# Altium schematic sheet Comperator.SchDoc
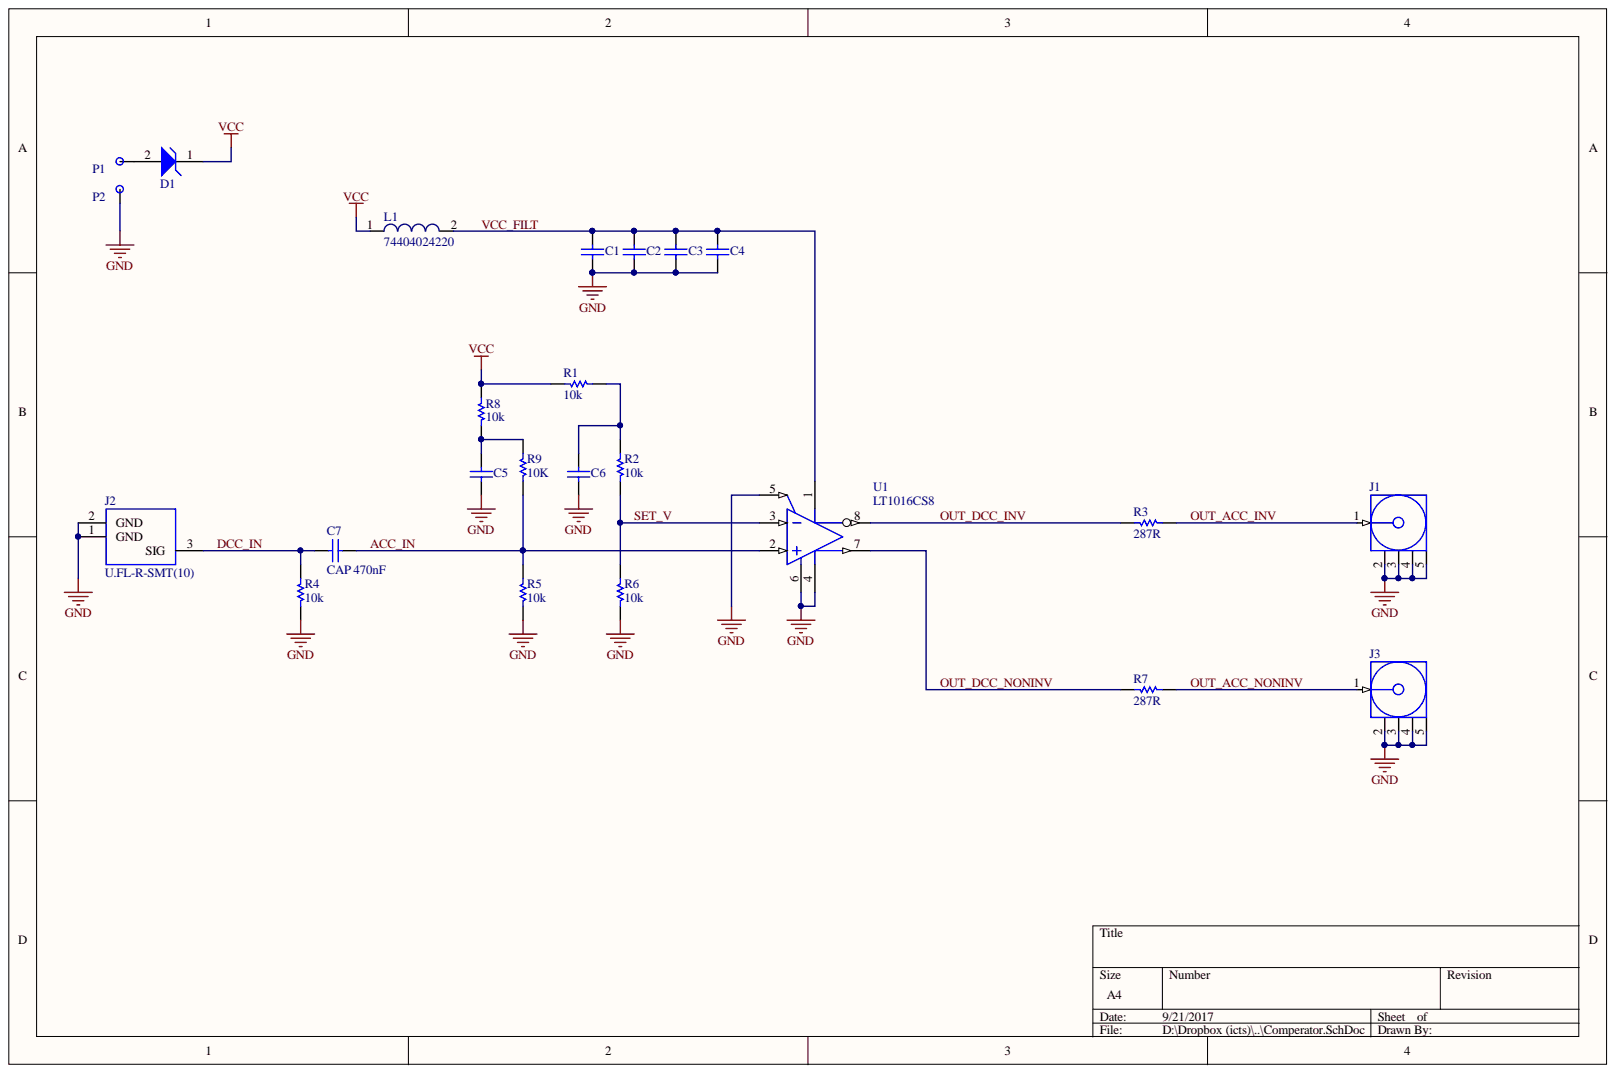
[diagram p0: Comperator.SchDoc]

## Parts
C1  CAP 10uF 10V 1206(3216)  [CAPC1206(3216)130_L]  (value not drawn)
C2  CAP 1uF 6.3V 0805(2012)  [CAPC0805(2012)100_L]  (value not drawn)
C3  CAP 1uF 6.3V 0805(2012)  [CAPC0805(2012)100_L]  (value not drawn)
C4  CAP 1uF 6.3V 0805(2012)  [CAPC0805(2012)100_L]  (value not drawn)
C5  CAP 1uF 6.3V 0805(2012)  [CAPC0805(2012)100_L]  (value not drawn)
C6  CAP 1uF 6.3V 0805(2012)  [CAPC0805(2012)100_L]  (value not drawn)
C7  CAP 470nF  value 470nF  [CAPC1210(3225)130_M]
D1  1SMF5920BT1G  [ONSC-SOD123-2-498_A_V]  (value not drawn)
J1  CONREVSMA003.062  [CON-SMA-EDGE062]  (value not drawn)
J2  U.FL-R-SMT(10)  [HRS-U.FL-R-SMT_V]
J3  CONREVSMA003.062  [CON-SMA-EDGE062]  (value not drawn)
L1  74404024220  [WE-LQS 252012]
P1  PinHeader 2.54mm 1x1  [PinHeader]  (value not drawn)
P2  PinHeader 2.54mm 1x1  [PinHeader]  (value not drawn)
R1  10k  [RESC0805(2012)_L]
R2  10k  [RESC0805(2012)_L]
R3  287R  [RESC0805(2012)_L]
R4  10k  [RESC0805(2012)_L]
R5  10k  [RESC0805(2012)_L]
R6  10k  [RESC0805(2012)_L]
R7  287R  [RESC0805(2012)_L]
R8  10k  [RESC0805(2012)_L]
R9  10K  [RESC0805(2012)_L]
U1  LT1016CS8  [LT-S8_N]

## Nets
GND: C1.1 C2.1 C3.1 C4.1 C5.1 C6.1 J1.2 J1.3 J1.4 J1.5 J2.1 J2.2 J3.2 J3.3 J3.4 J3.5 P2.1 R4.2 R5.2 R6.2 U1.4 U1.5 U1.6
VCC: D1.1 L1.1 R1.1 R8.1
ACC_IN: C7.1 R5.1 R9.2 U1.2
DCC_IN: C7.2 J2.3 R4.1
OUT_ACC_INV: J1.1 R3.1
OUT_ACC_NONINV: J3.1 R7.1
OUT_DCC_INV: R3.2 U1.8
OUT_DCC_NONINV: R7.2 U1.7
SET_V: R2.2 R6.1 U1.3
VCC_FILT: C1.2 C2.2 C3.2 C4.2 L1.2 U1.1
NetC5_2: C5.2 R8.2 R9.1
NetC6_2: C6.2 R1.2 R2.1
NetD1_2: D1.2 P1.1

## Library data of the parts
C1 = CMP-1037-04970-1: CAP 10uF 10V -20% to +80% 1206 (3216 Metric) Thickness 1.3mm SMD; Case-EIA: 1206; Case-Metric: 3216; Component Kind: Standard; Library Name: Altium Content Vault; Max Thickness: 1.3 mm; Rated Voltage: 10 V; Technology: SMT; Tolerance: -20% to +80%; Value: 10uF
C2-C6 = CMP-1036-04734-1: CAP 1uF 6.3V ±20% 0805 (2012 Metric) Thickness 1mm SMD; Case-EIA: 0805; Case-Metric: 2012; Tolerance: Â±20%; Component Kind: Standard; Library Name: Altium Content Vault; Max Thickness: 1 mm; Rated Voltage: 6.3 V; Technology: SMT; Value: 1uF
C7 = CMP-1038-01934-1: CAP 470nF 50V ±10% 1210 (3225 Metric) Thickness 1.3mm SMD; Case-EIA: 1210; Case-Metric: 3225; Tolerance: Â±10%; Component Kind: Standard; Library Name: Altium Content Vault; Max Thickness: 1.3 mm; Rated Voltage: 50 V; Technology: SMT
D1 = CMP-1060-00636-1: 2.5 W Zener Diode, 2-Pin SOD123, Pb-Free, Tape and Reel; Manufacturer: On Semiconductor; VZ Typ (V): 6.2; Component Kind: Standard; ComponentLink1Description: Manufacturer URL; ComponentLink1URL: http://www.onsemi.com/; ComponentLink2Description: Datasheet; ComponentLink2URL: http://www.onsemi.cn/pub_link/Collateral/1SMF5920B-D.PDF; ComponentLink3Description: Package Specification; ComponentLink3URL: http://www.onsemi.cn/pub_link/Collateral/1SMF5920B-D.PDF; DatasheetVersion: Rev. P0; Library Name: Altium Content Vault; Mounting Technology: Surface Mount; PackageDescription: 2-Pin SOD, Body 1.65 x 2.7 mm; PackageReference: SOD-123-2-498_A; PackageVersion: Rev. A; Packing: Tape and Reel; PartNumber: 1SMF5920BT1G; RoHS: Pb-Free
J1 J3 = CMP-0168-00046-1: PCB_Layout: Pads complies with manufacturer's recommendation.; Component Kind: Standard; DatasheetVersion: rev1.0, Jun-2006; Library Name: Altium Content Vault; PackageReference: CON-SMA-EDGE062; PackageVersion: rev1.0, Jun-2006
J2 = CMP-0029-00003-1: Ultra Small Surface Mount Coaxial Connector, 50 Ohm, -40 to 90 degC, 3-Pin SMD, RoHS, Tape and Reel; Current Rating: 3 A; Colour: Black; Component Kind: Standard; ComponentLink1Description: Manufacturer URL; ComponentLink1URL: https://www.hirose.com; ComponentLink2Description: Datasheet; ComponentLink2URL: https://www.hirose.com/product/en/download_file/key_name/U.FL/category/Catalog/doc_file_id/31697/?file_category_id=4&item_id=15&is_series=1; Contact Finish: Lead; Contact Material: Bronze; Contact Plating: Tin; DatasheetVersion: Aug-16; Height: 8.13 mm; Lead Pitch: 2.54 mm; Length: 101.19 mm; Library Name: Altium Content Vault; Max Operating Temperature: 105degC; Min Operating Temperature: -65degC; Mount: Through Hole; PackageDescription: 3-Pin Surface Mount Device, Body 2.6 x 2.6 mm; Package Quantity: 50; PackageReference: U.FL-R-SMT; Packaging: Bulk; Pins: 80; Radiation Hardening: No; RoHSCompliant: True; Width: 4.88 mm
L1 = CMP-1354-00001-1: WE-LQS SMD Power Inductor, L=22.0 µH; Manufacturer: Wuerth Elektronik; Inductance: 22.0 ÂµH; Component Kind: Standard; ComponentLink1Description: Manufacturer URL; ComponentLink1URL: http://www.we-online.com; ComponentLink2Description: Datasheet; ComponentLink2URL: http://katalog.we-online.de/pbs/datasheet/74404024220.pdf; Library Name: Altium Content Vault; PackageReference: WE-LQS 252012; PartNumber: 74404024220
R1-R9 = CMP-1013-00180-1: 1R21 0.125W 1% 0805 (2012 Metric)  SMD; Case-EIA: 0805; Case-Metric: 2012; Value: 1.21 Ohm; Component Kind: Standard; Library Name: Altium Content Vault; Power: 0.125 W; Technology: SMT; Tolerance: 1 %
U1 = CMP-0360-00596-2: UltraFast Precision 10 ns Comparator, 1 Channel, 4.5 to + / - 5 V, 0 to +70 degC, 8-pin SOP (S8); Generic Part Number: LT1016; Manufacturer: Linear Technology; Channels (#): 1; Prop Delay (us): 0.009; Supply Current (uA) Typical: 35000; Vos (mV) Guaranteed: 3; Vs Max (V): 10; Vs Min (V): 4.5; Component Kind: Standard; ComponentLink1Description: Manufacturer URL; ComponentLink1URL: http://www.linear.com/; ComponentLink2Description: Datasheet; ComponentLink2URL: http://cds.linear.com/docs/en/datasheet/1016fc.pdf; ComponentLink3Description: Package Specification; ComponentLink3URL: http://cds.linear.com/docs/en/packaging/05081610_G_SO8.pdf; DatasheetVersion: Rev C; Features: Comparator, Output Latch; Library Name: Altium Content Vault; Mounting Technology: Surface Mount; PackageDescription: 8-Pin Plastic Small Outline (Narrow .150 Inch), Body 4.9 x 3.9 mm, Pitch 1.27 mm; PackageReference: S8; PartNumber: LT1016CS8; RoHS: N; Supply Voltage Range: 4.5 to + / -5 V; Vos (mV) Typical: 1
P1 P2 = PinHeader 2.54mm 1x1: Component Kind: Standard; Library Name: BNC.SchLib
